# Altium schematic sheet p12_Spi_Flash_Memory.SchDoc
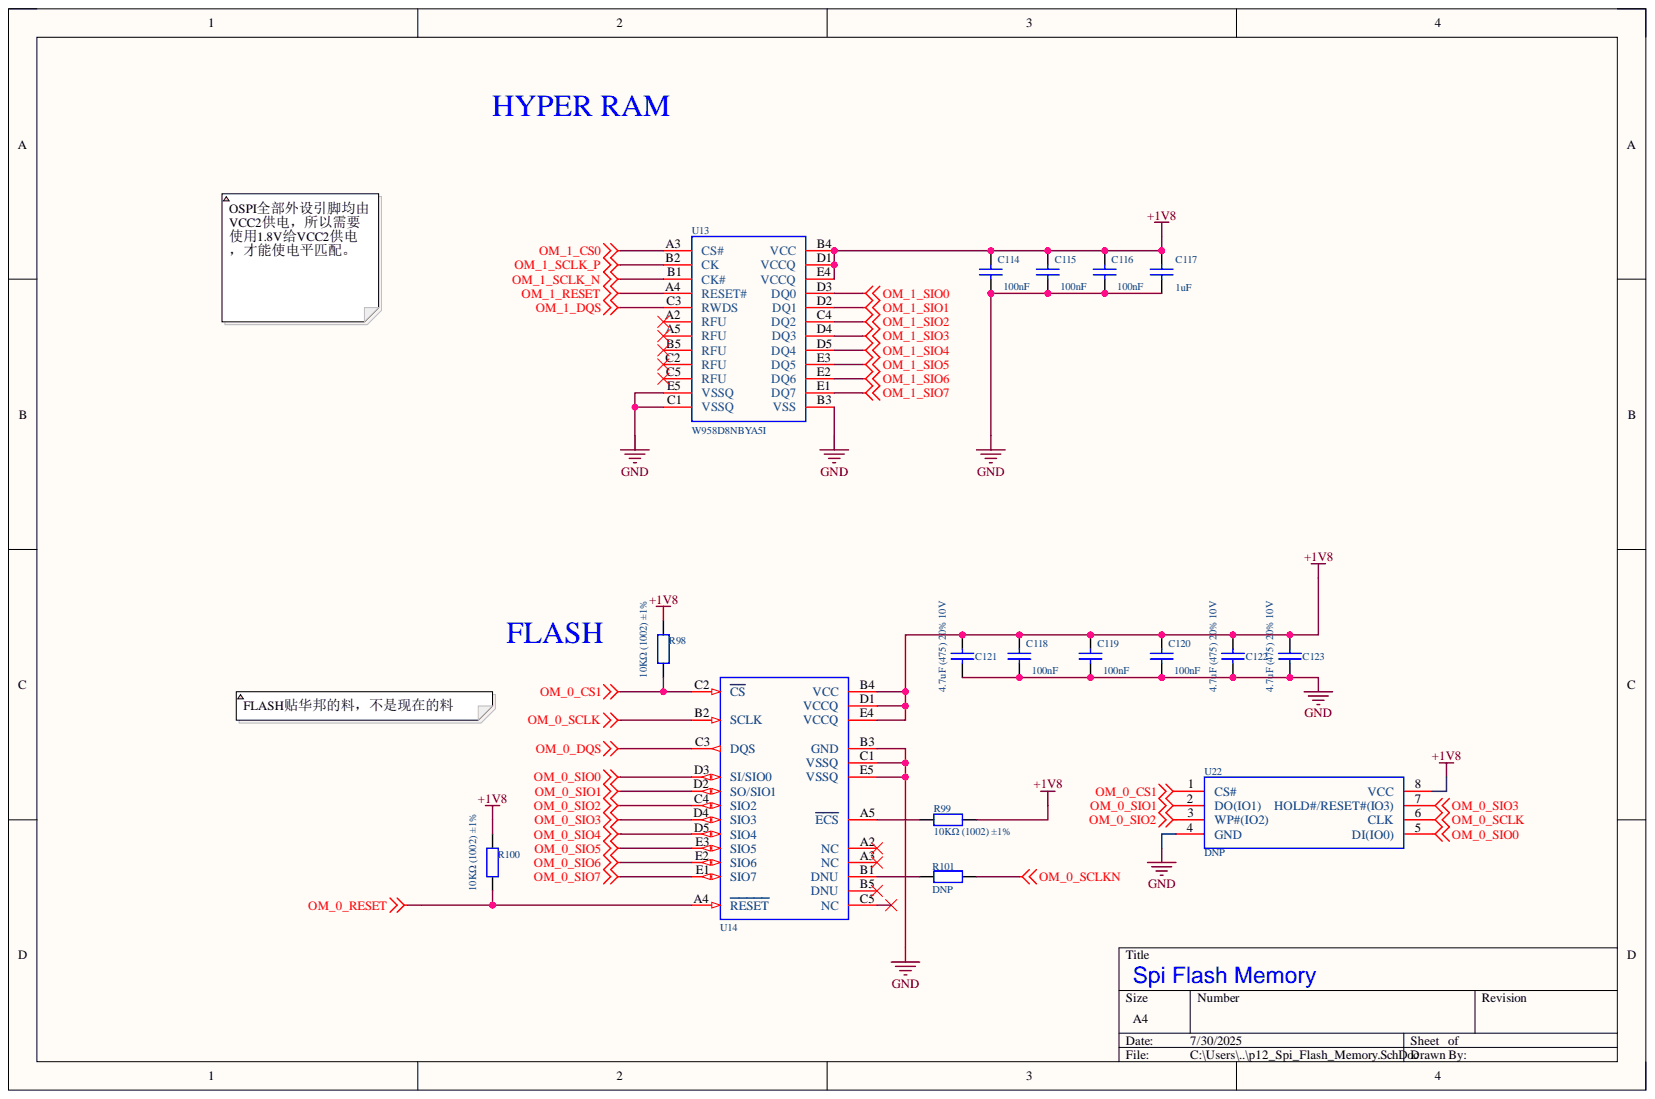
[diagram p0: p12_Spi_Flash_Memory.SchDoc]

## Parts
C114  Capacitance: 100nF  [C0402]  (hidden comment: 100 nf (0.1uF) 16V)
C115  Capacitance: 100nF  [C0402]  (hidden comment: 100 nf (0.1uF) 16V)
C116  Capacitance: 100nF  [C0402]  (hidden comment: 100 nf (0.1uF) 16V)
C117  Capacitance: 1uF  [C0402]  (hidden comment: 1uF 16V)
C118  Capacitance: 100nF  [C0402]  (hidden comment: 100 nf (0.1uF) 16V)
C119  Capacitance: 100nF  [C0402]  (hidden comment: 100 nf (0.1uF) 16V)
C120  Capacitance: 100nF  [C0402]  (hidden comment: 100 nf (0.1uF) 16V)
C121  4.7uF (475) 20% 10V  [C0402]
C122  4.7uF (475) 20% 10V  [C0402]
C123  4.7uF (475) 20% 10V  [C0402]
R98  10KΩ (1002) ±1%  [R0402]
R99  10KΩ (1002) ±1%  [R0402]
R100  10KΩ (1002) ±1%  [R0402]
R101  DNP  [R0402]
U13  W958D8NBYA5I  [T-PBGA-24_L8.0-W6.0-P1.00-TL_MICRON_12]
U14  MX66UW1G45GXDI00  [ICC_BGA24_5X5-BALL-ARRAY_6X8_P1]  (value not drawn)
U22  DNP  [SOP-8_L5.3-W5.2-P1.27-LS7.9-BL]

## Nets
+1V8: C114.2 C115.2 C116.2 C117.2 C118.2 C119.2 C120.2 C121.2 C122.2 C123.2 R98.1 R99.1 R100.1 U13.B4(VCC) U13.D1(VCCQ) U13.E4(VCCQ) U14.B4(VCC) U14.D1(VCCQ) U14.E4(VCCQ) U22.8(VCC)
GND: C114.1 C115.1 C116.1 C117.1 C118.1 C119.1 C120.1 C121.1 C122.1 C123.1 U13.B3(VSS) U13.C1(VSSQ) U13.E5(VSSQ) U14.B3(GND) U14.C1(VSSQ) U14.E5(VSSQ) U22.4(GND)
OM_0_CS1: R98.2 U14.C2(CS) U22.1(CS#)
OM_0_DQS: U14.C3(DQS)
OM_0_RESET: R100.2 U14.A4(RESET)
OM_0_SCLK: U14.B2(SCLK) U22.6(CLK)
OM_0_SCLKN: R101.2
OM_0_SIO0: U14.D3(SI/SIO0) U22.5(DI(IO0))
OM_0_SIO1: U14.D2(SO/SIO1) U22.2(DO(IO1))
OM_0_SIO2: U14.C4(SIO2) U22.3(WP#(IO2))
OM_0_SIO3: U14.D4(SIO3) U22.7(HOLD#/RESET#(IO3))
OM_0_SIO4: U14.D5(SIO4)
OM_0_SIO5: U14.E3(SIO5)
OM_0_SIO6: U14.E2(SIO6)
OM_0_SIO7: U14.E1(SIO7)
OM_1_CS0: U13.A3(CS#)
OM_1_DQS: U13.C3(RWDS)
OM_1_RESET: U13.A4(RESET#)
OM_1_SCLK_N: U13.B1(CK#)
OM_1_SCLK_P: U13.B2(CK)
OM_1_SIO0: U13.D3(DQ0)
OM_1_SIO1: U13.D2(DQ1)
OM_1_SIO2: U13.C4(DQ2)
OM_1_SIO3: U13.D4(DQ3)
OM_1_SIO4: U13.D5(DQ4)
OM_1_SIO5: U13.E3(DQ5)
OM_1_SIO6: U13.E2(DQ6)
OM_1_SIO7: U13.E1(DQ7)
NetR99_2: R99.2 U14.A5(ECS)
NetR101_1: R101.1 U14.B1(DNU)

Not connected: U13 A2(RFU) A5(RFU) B5(RFU) C2(RFU) C5(RFU); U14 A2(NC) A3(NC) B5(DNU) C5(NC)

## Library data of the parts
C114-C116 C118-C120 = CAP-000030-01: Ceramic Chip Capacitor 0402 100 nf (0.1uF) 16V; Legacy Part Number: 151-10004022; Manufacturer: Yageo; Manufacturer Part Number 1: CC0402KRX7R8BB104; Dialectric: X7R; Notes: ANY EQUIVALENT; Tolerance: 10%; Component Kind: Standard; Library Name: ra8p1_renesas.SCHLIB; Manufacturer 1: Yageo; Manufacturer 2: Kemet; Manufacturer 3: AVX; Manufacturer Part Number 2: C0402C104K4RACTU; Manufacturer Part Number 3: 0402YC104KAT2A; Mfg: Yageo; Mfg P/N: CC0402KRX7R8BB104; Mfg Part Number: CC0402KRX7R8BB104; Package: 0402; PN: CC0402KRX7R8BB104; Supplier 1: Arrow Electronics; Supplier 2: Digi-Key; Supplier 3: Newark; Supplier 4: Mouser; Supplier Part Number 1: CC0402KRX7R8BB104; Supplier Part Number 2: 311-2077-1-ND; Supplier Part Number 3: 40AJ6370; Supplier Part Number 4: 603-CC402KRX7R8BB104; Voltage: 16V
C117 = CAP-000063-01: Ceramic Chip Capacitor 0402 1uF 16V; Manufacturer: Murata; Manufacturer Part Number 1: GRM155R61E105MA12D; Dialectric: X5R; Notes: ANY EQUIVALENT; Tolerance: 10%; Value: 1u; Component Kind: Standard; Library Name: ra8p1_renesas.SCHLIB; Manufacturer 1: Murata; Manufacturer 2: Samsung; Manufacturer Part Number 2: CL05A105KO5NNNC; Mfg: Murata; Mfg P/N: GRM155R61E105MA12D; Mfg Part Number: GRM155R61E105MA12D; Package: 0402; PN: GRM155R61E105MA12D; Simulation: C0402_X5R_1UF; Supplier 1: Digi-Key; Supplier 2: Digi-Key; Supplier 3: Digi-Key; Supplier Part Number 1: 1276-1067-1-ND; Supplier Part Number 2: 1276-1067-6-ND; Supplier Part Number 3: 490-10018-1-ND; Voltage: 16V
C121-C123 = 0402 4.7uF (475) 20% 10V: 贴片电容; Component Kind: Standard; ComponentLink1Description: 供应商链接; ComponentLink1URL: http://www.szlcsc.com/product/details_24469.html; Library Name: Cap.SchLib; Package: 0402; Supplier: LC; SuppliersPartNumber: C23733
R98-R100 = 0402 10KΩ (1002) 1%: 贴片电阻，10K; Component Kind: Standard; ComponentLink1Description: 供应商链接; ComponentLink1URL: https://item.szlcsc.com/26487.html?fromZone=l_b__%2522brand%2522&spm=sc.bid.xh1.zy.n&lcsc_vid=FANcVFBQFQMNX1cFFAdZVVZXEgdeAgdWFlQNUVAHT1ExVlNSRFhXVlJQQFlYVzsOAxUeFF5JWA0HFBBIBBsCBBcFWQIBCksEBQAAA0tfTk8GEwkK; Library Name: RES.SchLib; Package: 0402; Supplier: LC; SuppliersPartNumber: C25744
R101 = RES-000221-01: Chip Resistor Thick Film 0402  0R00 1% 1/4W; Legacy Part Number: 150-00000004; Manufacturer: Yageo; Manufacturer Part Number 1: RC1206JR-070RL; Notes: ANY EQUIVALENT; Resistance: 0R00(DNP); ClassName: MCU; Component Kind: Standard; Library Name: Resistors.IntLib; Manufacturer 1: Yageo; Mfg: Yageo; Mfg Part Number: RC1206JR-070RL; Package: 1206; PN: RC1206JR-070RL; Power: 1/4W; Supplier 1: Digi-Key; Supplier 2: Future Electronics; Supplier 3: Arrow Electronics; Supplier 4: Avnet; Supplier 5: Digi-Key; Supplier 6: Mouser; Supplier Part Number 1: P0.0ECT-ND; Supplier Part Number 2: 1414689; Supplier Part Number 3: RC1206JR-070RL; Supplier Part Number 4: RC1206JR-070RL; Supplier Part Number 5: 311-0.0ERCT-ND; Supplier Part Number 6: 603-RC1206JR-070RL; Tolerance: 1%
U13 = W958D8NBYA5I: Manufacturer: WINBOND(华邦); Add into BOM: yes; Component Kind: Standard; Convert to PCB: yes; Datasheet: https://atta.szlcsc.com/upload/public/pdf/source/20240909/0D346AE706C66FA02C3A6144B94049CA.pdf; Device: W958D8NBYA5I; JLCPCB Part Class: Extended Part; LCSC Part Name: W958D8NBYA5I; Library Name: ra8p1_v0.1.SCHLIB; Manufacturer Part: W958D8NBYA5I; PCB3D: T-PBGA-24_L8.0-W6.0-P1.00-TL_MICRON_12; Supplier: LCSC; Supplier Footprint: TFBGA-24(6x8); Supplier Part: C7420405; Symbol: W958D8NBYA5I
U14 = MCD-02601: Memory, Octo-SPI-NOR, 1Gb,x1/x8, Read-While-Write, 133/200MHz, 1.8V, DTR, BGA24; Manufacturer: MACRONIX; Part_Preference: Recommended; Part_Type: IC; ID: 4707; ShowFields: YES; Component Kind: Standard; ComponentLink1URL: http://tunmcdsvn.tun.st.com/Component_Documentation_Library/Trunk/IC/MACRONIX_MX66UW1G45G; Internal_Part_Number: MCD-02601; Library Name: ra8p1_v0.1.SCHLIB; Manufacturer_Part_Number: MX66UW1G45GXDI00; Order_code_Digikey: N/A; Order_code_Farnell: N/A; Order_code_RS: N/A; Package: BGA24; Status: Released; Value: MX66UW1G45GXDI00
U22 = W25Q64JWSSIQ: Manufacturer: WINBOND(华邦); Add into BOM: yes; Block Erase Time(tBE): 120ms@(32KB); Clock Frequency: 133MHz; Data Retention - TDR (Year): 20年; Interface: SPI; Memory Size: 64Mbit; Page Programming Time (Tpp): 800us; Symbol: W25Q64JWSSIQ_C2985658; Voltage - Supply: 1.7V~1.95V; Component Kind: Standard; Convert to PCB: yes; Datasheet: https://atta.szlcsc.com/upload/public/pdf/source/20210420/C2595075_E7A7725B7CF2DE50C07916BF10CAF3A1.pdf; Description: W25Q64JWSSIQ; Device: W25Q64JWSSIQ; JLCPCB Part Class: Extended Part; LCSC Part Name: W25Q64JWSSIQ; Library Name: ra8p1_v0.2.SCHLIB; Manufacturer Part: W25Q64JWSSIQ; Operating Temperature: -40℃~+85℃; PCB3D: SOP-8_L5.3-W5.2-P1.27-LS7.9-BL; Supplier: LCSC; Supplier Footprint: SOIC-8-208mil; Supplier Part: C2985658
